# Altium schematic sheet DC_LED_Driver.SchDoc(DC_LED_Driver)
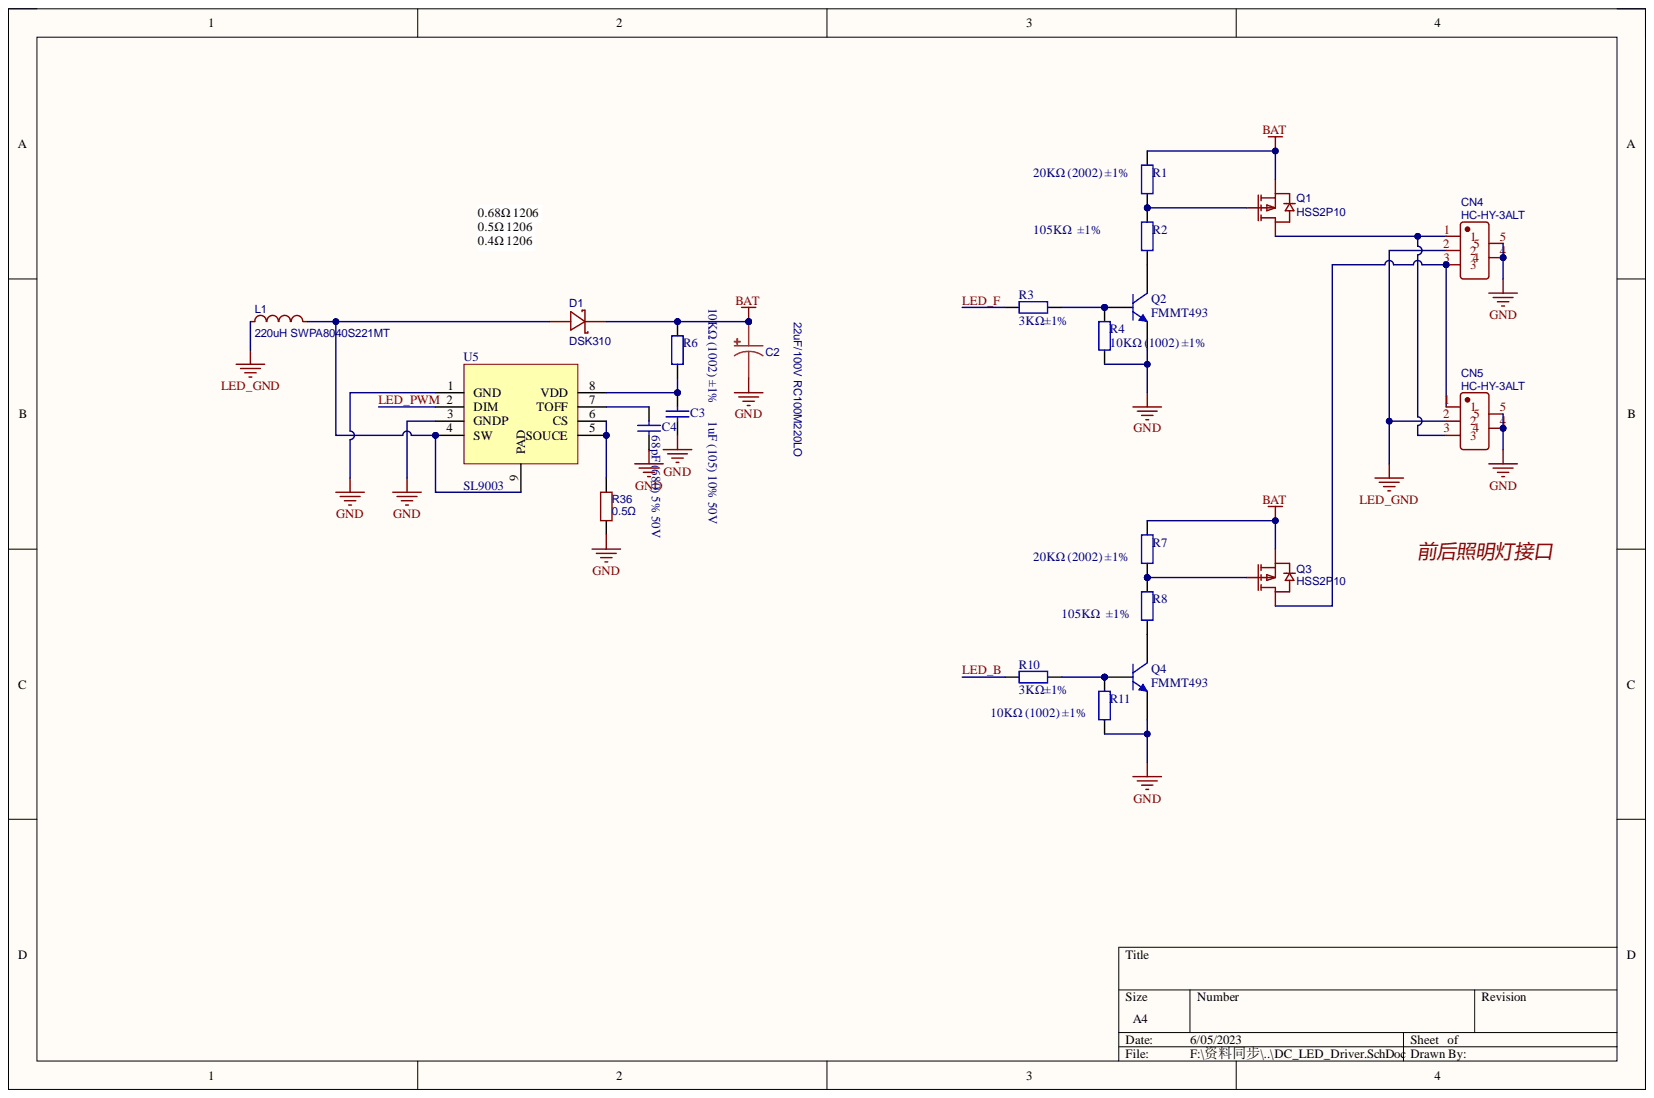
[diagram p0: DC_LED_Driver.SchDoc]

## Parts
C2  22uF/100V RC100M220LO  [CAP-TH_BD6.3-P2.50-D1.0-FD]
C3  1uF (105) 10% 50V  [0603]
C4  68pF (680) 5% 50V  [0402]
CN4  HC-HY-3ALT  [CONN-SMD_HC-HY-3ALT]
CN5  HC-HY-3ALT  [CONN-SMD_HC-HY-3ALT]
D1  DSK310  [SOD-123_L2.7-W1.8-LS3.7-RD]
L1  220uH SWPA8040S221MT  BOM_Manufacturer Part: SWPA8040S221MT  [IND-SMD_L8.0-W8.0]
Q1  HSS2P10  [SOT-23-3_L2.9-W1.6-P1.90-LS2.8-BR]
Q2  FMMT493  [SOT-23(SOT-23-3)]
Q3  HSS2P10  [SOT-23-3_L2.9-W1.6-P1.90-LS2.8-BR]
Q4  FMMT493  [SOT-23(SOT-23-3)]
R1  20KΩ (2002) ±1%  [0402]
R2  105KΩ  ±1%  [0402]
R3  3KΩ±1%  [0402]
R4  10KΩ (1002) ±1%  [0402]
R6  10KΩ (1002) ±1%  [1206_R]
R7  20KΩ (2002) ±1%  [0402]
R8  105KΩ  ±1%  [0402]
R10  3KΩ±1%  [0402]
R11  10KΩ (1002) ±1%  [0402]
R36  0.5Ω  [1206_R]
U5  SL9003  [ESOP-8_L4.9-W3.9-P1.27-LS6.0-BL-EP]

## Nets
BAT: C2.1 D1.1 Q1.2 Q3.2 R1.1 R6.2 R7.1
GND: C2.2 C3.1 C4.1 CN4.4 CN4.5 CN5.4 CN5.5 Q2.2 Q4.2 R4.2 R11.2 R36.2 U5.1(GND) U5.3(GNDP)
LED_GND: CN4.2 CN5.2 L1.1
LED_B: R10.2
LED_F: R3.2
LED_PWM: U5.2(DIM)
NetC3_2: C3.2 R6.1 U5.8(VDD)
NetC4_2: C4.2 U5.7(TOFF)
NetCN4_1: CN4.1 CN5.3 Q1.3
NetCN4_3: CN4.3 CN5.1 Q3.3
NetD1_2: D1.2 L1.2 U5.4(SW) U5.9(PAD)
NetQ1_1: Q1.1 R1.2 R2.1
NetQ2_1: Q2.1 R3.1 R4.1
NetQ2_3: Q2.3 R2.2
NetQ3_1: Q3.1 R7.2 R8.1
NetQ4_1: Q4.1 R10.1 R11.1
NetQ4_3: Q4.3 R8.2
NetR36_1: R36.1 U5.5(SOUCE) U5.6(CS)

## Library data of the parts
C2 = 22uF: BOM_Manufacturer: BERYL Electronic Tech; BOM_Manufacturer Part: RC100M220LO6.3*11TH-2A1E; Contributor: LCSC; nameAlias: Capacitance; BOM_JLCPCB Part Class: Extended Part; BOM_Supplier: LCSC; BOM_Supplier Part: C365778; Component Kind: Standard; spicePre: C; spiceSymbolName: RC100M220LO6.3*11TH-2A1E
C3 = 0603 1uF (105) 10% 50V: 贴片电容; Component Kind: Standard; ComponentLink1Description: 供应商链接; ComponentLink1URL: http://www.szlcsc.com/product/details_16531.html; Library Name: Miscellaneous Devices LC.IntLib; Package: 0603; Supplier: LC; SuppliersPartNumber: C15849
C4 = 0402 68pF (680) 5% 50V: 贴片电容; Component Kind: Standard; ComponentLink1Description: 供应商链接; ComponentLink1URL: http://www.szlcsc.com/product/details_15103.html; Library Name: Cap.SchLib; Package: 0402; Supplier: LC; SuppliersPartNumber: C14441
CN4 CN5 = HC-HY-3ALT: BOM_Manufacturer: HCTL(华灿天禄); Contributor: LCSC; BOM_Manufacturer Part: HC-HY-3ALT; BOM_Supplier: LCSC; BOM_Supplier Part: C2845717; Component Kind: Standard; spicePre: C; spiceSymbolName: HC-HY-3ALT
D1 = DSK310: BOM_Manufacturer: MDD; Contributor: LCSC; BOM_JLCPCB Part Class: Extended Part; BOM_Manufacturer Part: DSK310; BOM_Supplier: LCSC; BOM_Supplier Part: C106914; Component Kind: Standard; spicePre: D; spiceSymbolName: DSK310
L1 = 220uH: BOM_Manufacturer: Sunlord; nameAlias: Inductance; BOM_JLCPCB Part Class: Extended Part; BOM_Supplier: LCSC; BOM_Supplier Part: C86663; Component Kind: Standard; spicePre: L; spiceSymbolName: SWPA8040S221MT
Q1 Q3 = HSS2P10: BOM_Manufacturer: HUASHUO; Contributor: LCSC; BOM_JLCPCB Part Class: Extended Part; BOM_Manufacturer Part: HSS2P10; BOM_Supplier: LCSC; BOM_Supplier Part: C845592; Component Kind: Standard; spicePre: Q; spiceSymbolName: HSS2P10
Q2 Q4 = SOT-23 SS8050 Y1: 三极管; Component Kind: Standard; ComponentLink1Description: 供应商链接; ComponentLink1URL: http://www.szlcsc.com/product/details_2507.html; Library Name: Miscellaneous Devices LC.IntLib; Package: SOT-23; Supplier: LC; SuppliersPartNumber: C2150
R1 R7 = 0402 20KΩ (2002) 1%: 贴片电阻; Component Kind: Standard; ComponentLink1Description: 供应商链接; ComponentLink1URL: http://www.szlcsc.com/product/details_26508.html; Library Name: RES.SchLib; Package: 0402; Supplier: LC; SuppliersPartNumber: C25765
R2 R8 = 0402 100KΩ (1003) 1%: 贴片电阻; Component Kind: Standard; ComponentLink1Description: 供应商链接; ComponentLink1URL: http://www.szlcsc.com/product/details_26484.html; Library Name: RES.SchLib; Package: 0402; Supplier: LC; SuppliersPartNumber: C25741
R3 R10 = 0402 3.3KΩ (3301) 1%: 贴片电阻; Component Kind: Standard; ComponentLink1Description: 供应商链接; ComponentLink1URL: http://www.szlcsc.com/product/details_26633.html; Library Name: RES.SchLib; Package: 0402; Supplier: LC; SuppliersPartNumber: C25890
R4 R11 = 0603 10KΩ (1002) 1%: 贴片电阻; Component Kind: Standard; ComponentLink1Description: 供应商链接; ComponentLink1URL: http://www.szlcsc.com/product/details_26547.html; Library Name: Miscellaneous Devices LC.IntLib; Package: 0603; Supplier: LC; SuppliersPartNumber: C25804
R6 = 1206 10KΩ (1002) 1%: 贴片电阻; Component Kind: Standard; ComponentLink1Description: 供应商链接; ComponentLink1URL: http://www.szlcsc.com/product/details_18590.html; Library Name: RES.SchLib; Package: 1206; Supplier: LC; SuppliersPartNumber: C17902
R36 = 0.5Ω: BOM_Manufacturer: RALEC; BOM_Manufacturer Part: RTT06R500FTP; nameAlias: Resistance; Resistance (Ohms): 0.5; BOM_JLCPCB Part Class: Extended Part; BOM_Supplier: LCSC; BOM_Supplier Part: C104947; Component Kind: Standard; spicePre: R; spiceSymbolName: RTT06R500FTP
U5 = SL9003: Component Kind: Standard; Library Name: LCM.SchLib
